# Altium schematic sheet Sheet1.SchDoc
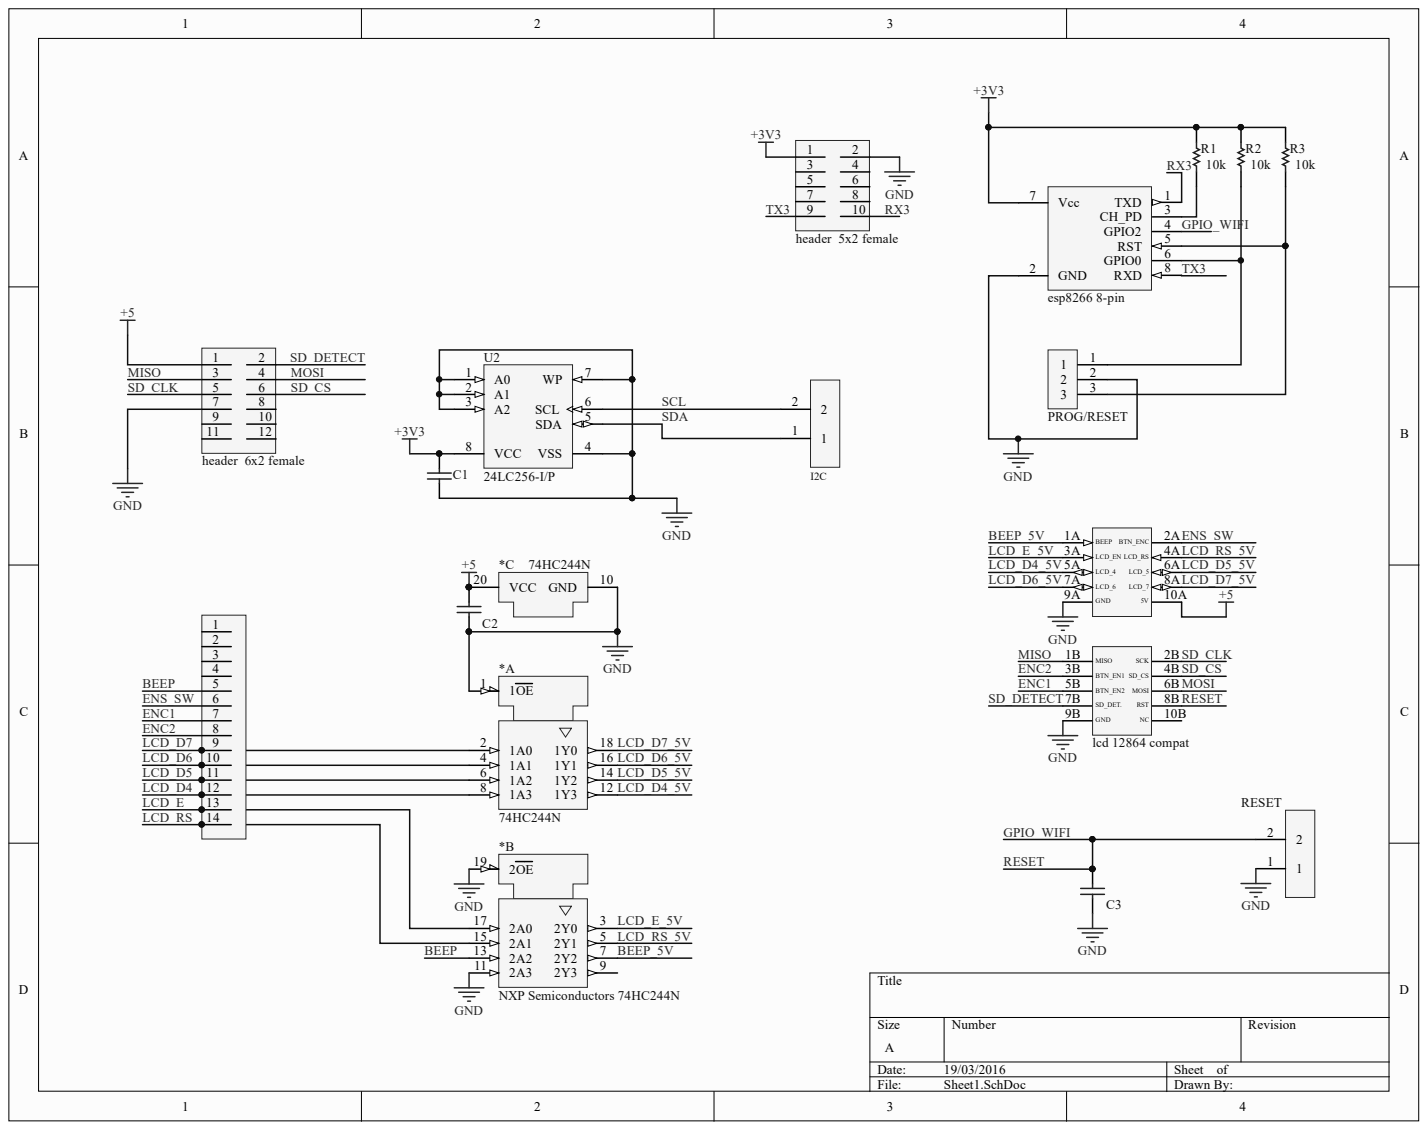
[diagram p0: Sheet1.SchDoc]

## Parts
*  74HC244N  [PCB-8634235-2]  (parts A,B,C)
C1  Kemet C1206C104K3HACTU  [CAPC3216X100X50LL20T25]  (value not drawn)
C2  Kemet C1206C104K3HACTU  [CAPC3216X100X50LL20T25]  (value not drawn)
C3  Kemet C1206C104K3HACTU  [CAPC3216X100X50LL20T25]  (value not drawn)
esp8266  esp8266 8-pin  [PCB-ykd3lizt1pl853gzhja1-1]
header5x2  header  5x2 female  [PCB-ivlc5l51g9mfu00c1vqy-1]
header6x2  header  6x2 female  [PCB-ivlc5l51g9mfu00c1vqy-1]
header14x1_female  header  14x1 female  [PCB-ivlc5l51g9mfu00c1vqy-1]  (value not drawn)
I2C  I2C  [PCB-2485063-1]
lcd_12864  lcd 12864 compat  [PCB-ywo058g3nnwu50u8glgr-1]
PROG/RESET  PROG/RESET  [PCB-2485063-1]
R1  10k  [RESC3216X50X50ML20T20]
R2  10k  [RESC3216X50X50ML20T20]
R3  10k  [RESC3216X50X50ML20T20]
RESET  RESET  [PCB-2485063-1]
U2  24LC256-I/P  [PDIP300-P8]

## Nets
+3V3: C1.2 esp8266.7(Vcc) header5x2.1 R1.2 R2.2 R3.2 U2.8(VCC)
+5: *.20(VCC) C2.1 header6x2.1 lcd_12864.10A(5V)
GND: *.1(1OE) *.10(GND) *.11(2A3) *.19(2OE) C1.1 C2.2 C3.2 esp8266.2(GND) header5x2.2 header6x2.7 lcd_12864.9A(GND) lcd_12864.9B(GND) PROG/RESET.2 RESET.1 U2.1(A0) U2.2(A1) U2.3(A2) U2.4(VSS) U2.7(WP)
BEEP: *.13(2A2) header14x1_female.5
BEEP_5V: *.7(2Y2) lcd_12864.1A(BEEP)
ENC1: header14x1_female.7 lcd_12864.5B(BTN_EN2)
ENC2: header14x1_female.8 lcd_12864.3B(BTN_EN1)
ENS_SW: header14x1_female.6 lcd_12864.2A(BTN_ENC)
GPIO_WIFI: C3.1 esp8266.4(GPIO2) lcd_12864.8B(RST) RESET.2
LCD_D4: *.8(1A3) header14x1_female.12
LCD_D4_5V: *.12(1Y3) lcd_12864.5A(LCD_4)
LCD_D5: *.6(1A2) header14x1_female.11
LCD_D5_5V: *.14(1Y2) lcd_12864.6A(LCD_5)
LCD_D6: *.4(1A1) header14x1_female.10
LCD_D6_5V: *.16(1Y1) lcd_12864.7A(LCD_6)
LCD_D7: *.2(1A0) header14x1_female.9
LCD_D7_5V: *.18(1Y0) lcd_12864.8A(LCD_7)
LCD_E: *.17(2A0) header14x1_female.13
LCD_E_5V: *.3(2Y0) lcd_12864.3A(LCD_EN)
LCD_RS: *.15(2A1) header14x1_female.14
LCD_RS_5V: *.5(2Y1) lcd_12864.4A(LCD_RS)
MISO: header6x2.3 lcd_12864.1B(MISO)
MOSI: header6x2.4 lcd_12864.6B(MOSI)
RX3: esp8266.1(TXD) header5x2.10
SCL: I2C.2 U2.6(SCL)
SD_CLK: header6x2.5 lcd_12864.2B(SCK)
SD_CS: header6x2.6 lcd_12864.4B(SD_CS)
SD_DETECT: header6x2.2 lcd_12864.7B(SD_DET.)
SDA: I2C.1 U2.5(SDA)
TX3: esp8266.8(RXD) header5x2.9
Netesp8266_3: esp8266.3(CH_PD) R1.1
Netesp8266_5: esp8266.5(RST) PROG/RESET.3 R3.1
Netesp8266_6: esp8266.6(GPIO0) PROG/RESET.1 R2.1

Not connected: * 9(2Y3); header5x2 3 4 5 6 7 8; header6x2 8 9 10 11 12; header14x1_female 1 2 3 4; lcd_12864 10B(NC)

## Library data of the parts
* = CMP-8634235-3: Logic IC Octal 3-State Driver DIL-20, 74HC244N; Lead-Free Status: Lead Free; Number of Bits: 8; Supply Voltage (DC): 5.0 V; Case/Package: DIP; Component Kind: Standard; Library Name: CommunityVault; Lifecycle Status: Not Listed by Manufacturer; Mounting Style: Through Hole; Number of Pins: 20; OctopartID: dc65156ff46303a2; Octopart Url: https://octopart.com/74hc244n-nxp+semiconductors-3424; Packaging: Tube; RoHS: Compliant
C1-C3 = CMP-124682-1: CAP CER 0.1UF 25V 10% X8R 1206; Capacitance: 0.1 µF; CiivaID: 124682; Material, Element: Ceramic; Special Features: High Temperature; Voltage, Rating: 25 VDC; Case Size: 1206 (3216 mm); Ciiva URL: https://ciiva.com/part/c1206c104k3hactu-124682; Component Kind: Standard; Dielectric Characteristic: X8R; Library Name: CommunityVault; Manufacturer Part Number: C1206C104K3HACTU; Termination: SMT; Tolerance: ±10 %
esp8266 = esp8266 8-pin-5: wifi module; CustomComponentID: ykd3lizt1pl853gzhja1; Component Kind: Standard; Library Name: FavoritesLibrary
header5x2 = CMP-ivlc5l51g9mfu00c1vqy-33: header 5x2 female; Component Kind: Standard; CustomComponentID: ivlc5l51g9mfu00c1vqy; Library Name: FavoritesLibrary
header6x2 = CMP-ivlc5l51g9mfu00c1vqy-35: header 6x2 female; Component Kind: Standard; CustomComponentID: ivlc5l51g9mfu00c1vqy; Library Name: CommunityVault
header14x1_female = CMP-ivlc5l51g9mfu00c1vqy-36: header 14x1 female; Component Kind: Standard; CustomComponentID: ivlc5l51g9mfu00c1vqy; Library Name: CommunityVault
I2C RESET = CMP-2485063-16: header 2pin JUMPER; Manufacturer: TXRX; Gender: HDR; Number of Contacts: 2; Pitch: 2.54 mm; Category: Connector Headers and PCB Receptacles; CiivaID: 2485063; Component Kind: Standard; Contact Plating: Tin; Library Name: CommunityVault; Number of Rows: 1; Termination Method: Solder; Type: Unshrouded Header
lcd_12864 = CMP-aeya5hd7cv2wvhv6lvgh-7: lcd 12864 base connector; Component Kind: Standard; CustomComponentID: aeya5hd7cv2wvhv6lvgh; Library Name: CommunityVault
PROG/RESET = CMP-2485063-34: header 3pin JUMPER; Gender: HDR; Number of Contacts: 2; Pitch: 2.54 mm; Category: Connector Headers and PCB Receptacles; CiivaID: 2485063; Component Kind: Standard; Library Name: CommunityVault; Manufacturer: jumper; Number of Rows: 1; Termination Method: Solder
R1-R3 = CMP-1865718-1: Thin Film Resistors 1/4W Regular 280Kohm 0.1% 25ppm; Manufacturer: Susumu; Manufacturer Part Number: RG3216P-2803-B-T1; Altezza custodia: 0.4 mm; Case Length: 3.2 mm; Case Width: 1.6 mm; Coefficiente di temperatura: 25 PPM / C; Confezione: Reel; Package/involucro: 1206 (3216 metric); Potenza nominale: 125 mW (1/8 W); Prodotto: Precision Resistors Thin Film SMD; Range di temperatura di lavoro: - 55 C to + 155 C; Resistance: 280 kOhms; Serie: RG; Stile di terminazione: SMD/SMT; Tensione nominale: 150 V; Case Code - in: 1206; Case Code - mm: 3216; Case Height: 0.4 mm; CiivaID: 1865718; Ciiva URL: https://ciiva.com/part/rg3216p-2803-b-t1-1865718; Component Kind: Standard; Dimensioni: 1.6 mm W x 3.2 mm L x 0.4 mm H; Dimensions: 1.6 mm W x 3.2 mm L x 0.4 mm H; Larghezza custodia: 1.6 mm; Library Name: CommunityVault; Lunghezza custodia: 3.2 mm; Operating Temperature Range: - 55 C to + 155 C; Package / Case: 1206 (3216 metric); Packaging: Reel; Power Rating: 125 mW (1/8 W); Product: Precision Resistors Thin Film SMD; Produttore: Susumu; Resistenza: 280 kOhms; RoHS: Details; Series: RG; Temperature Coefficient: 25 PPM / C; Termination Style: SMD/SMT; Tolerance: 0.1 %; Tolleranza: 0.1 %; Voltage Rating: 150 V
U2 = CMP-971515-2: 256Kbit, 400kHz, 2.5V, I2C Serial EEPROM, 8-Pin PDIP, Industrial Temperature; Access Time: 0.4 s; Frequency: 400000.0 Hz; Lead-Free Status: Lead Free; Memory Size: 32000 B; Supply Voltage (DC): 5.0 V; Case/Package: DIP; Component Kind: Standard; Library Name: CommunityVault; Lifecycle Status: Active; Mounting Style: Through Hole; Number of Pins: 8; OctopartID: d975107e3a4f06b2; Octopart Url: https://octopart.com/24lc256-i%2Fp-microchip-116316; Packaging: Tube; REACH SVHC Compliance: No SVHC; RoHS: Compliant
